# Altium schematic sheet SPI LVDS Master.SchDoc("SPI LVDS Master")
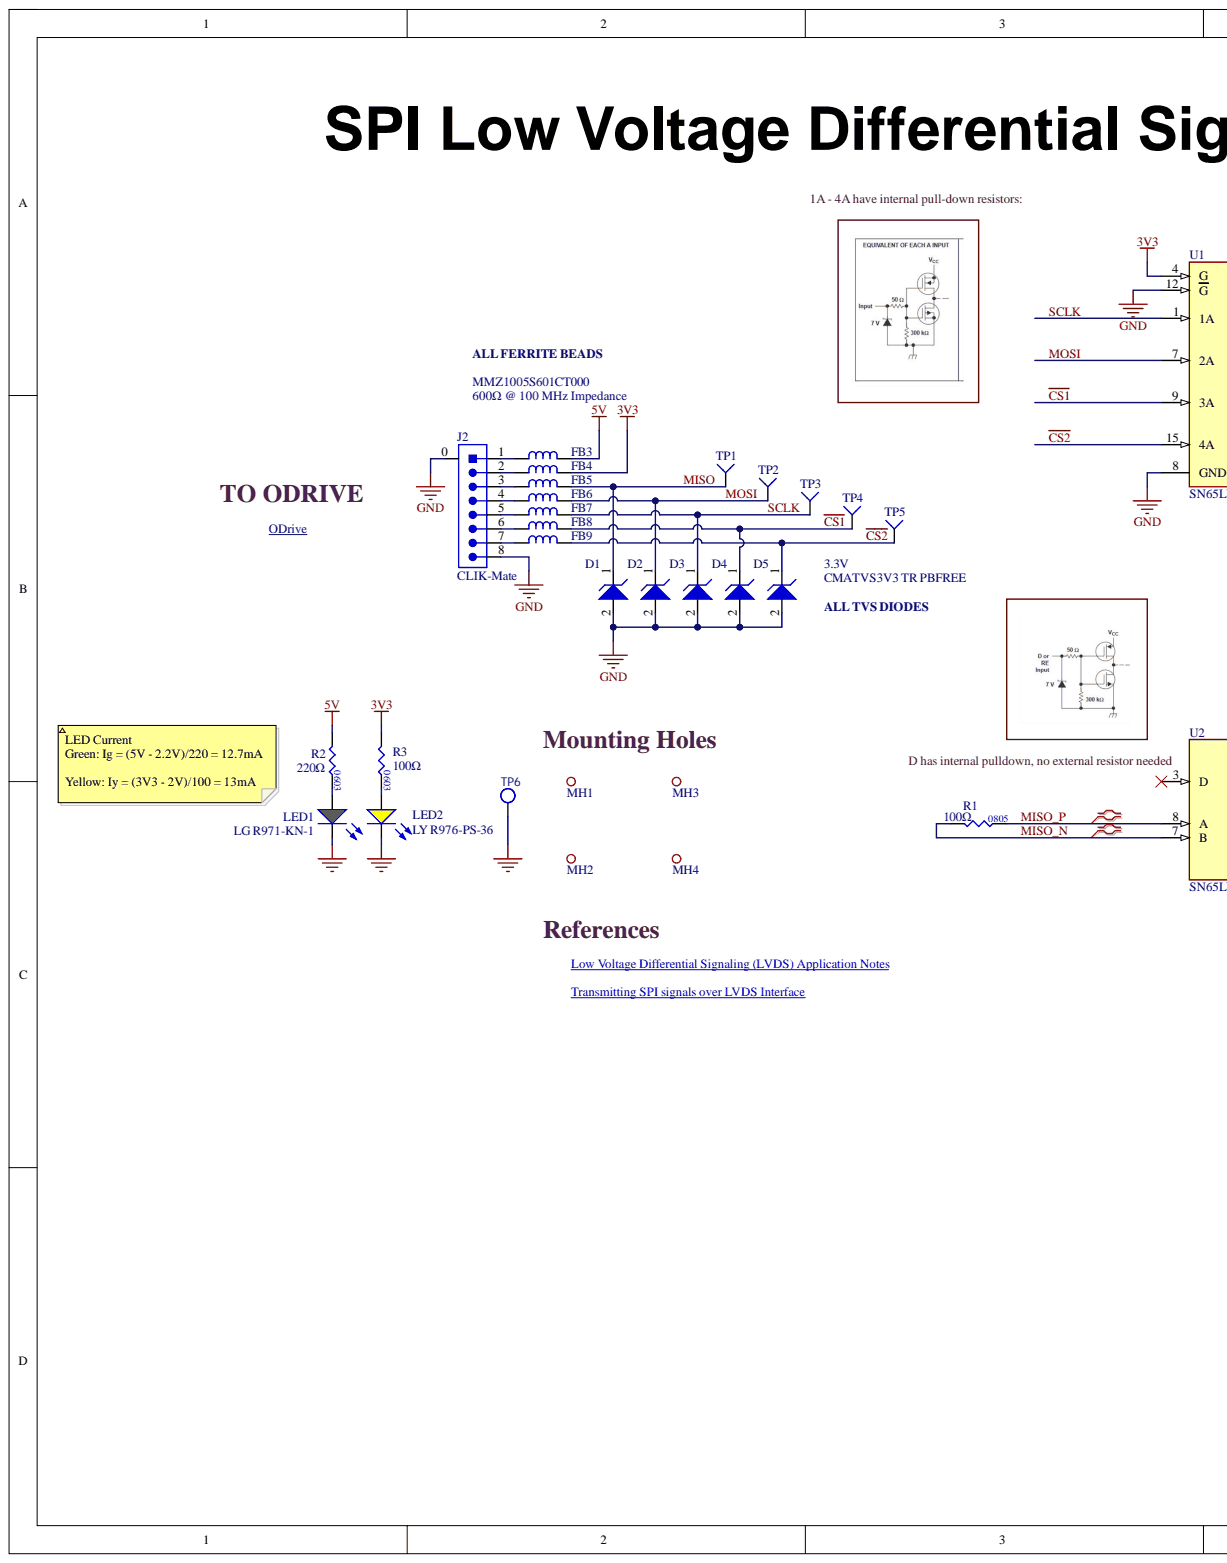
[diagram p0: SPI LVDS Master.SchDoc, left]
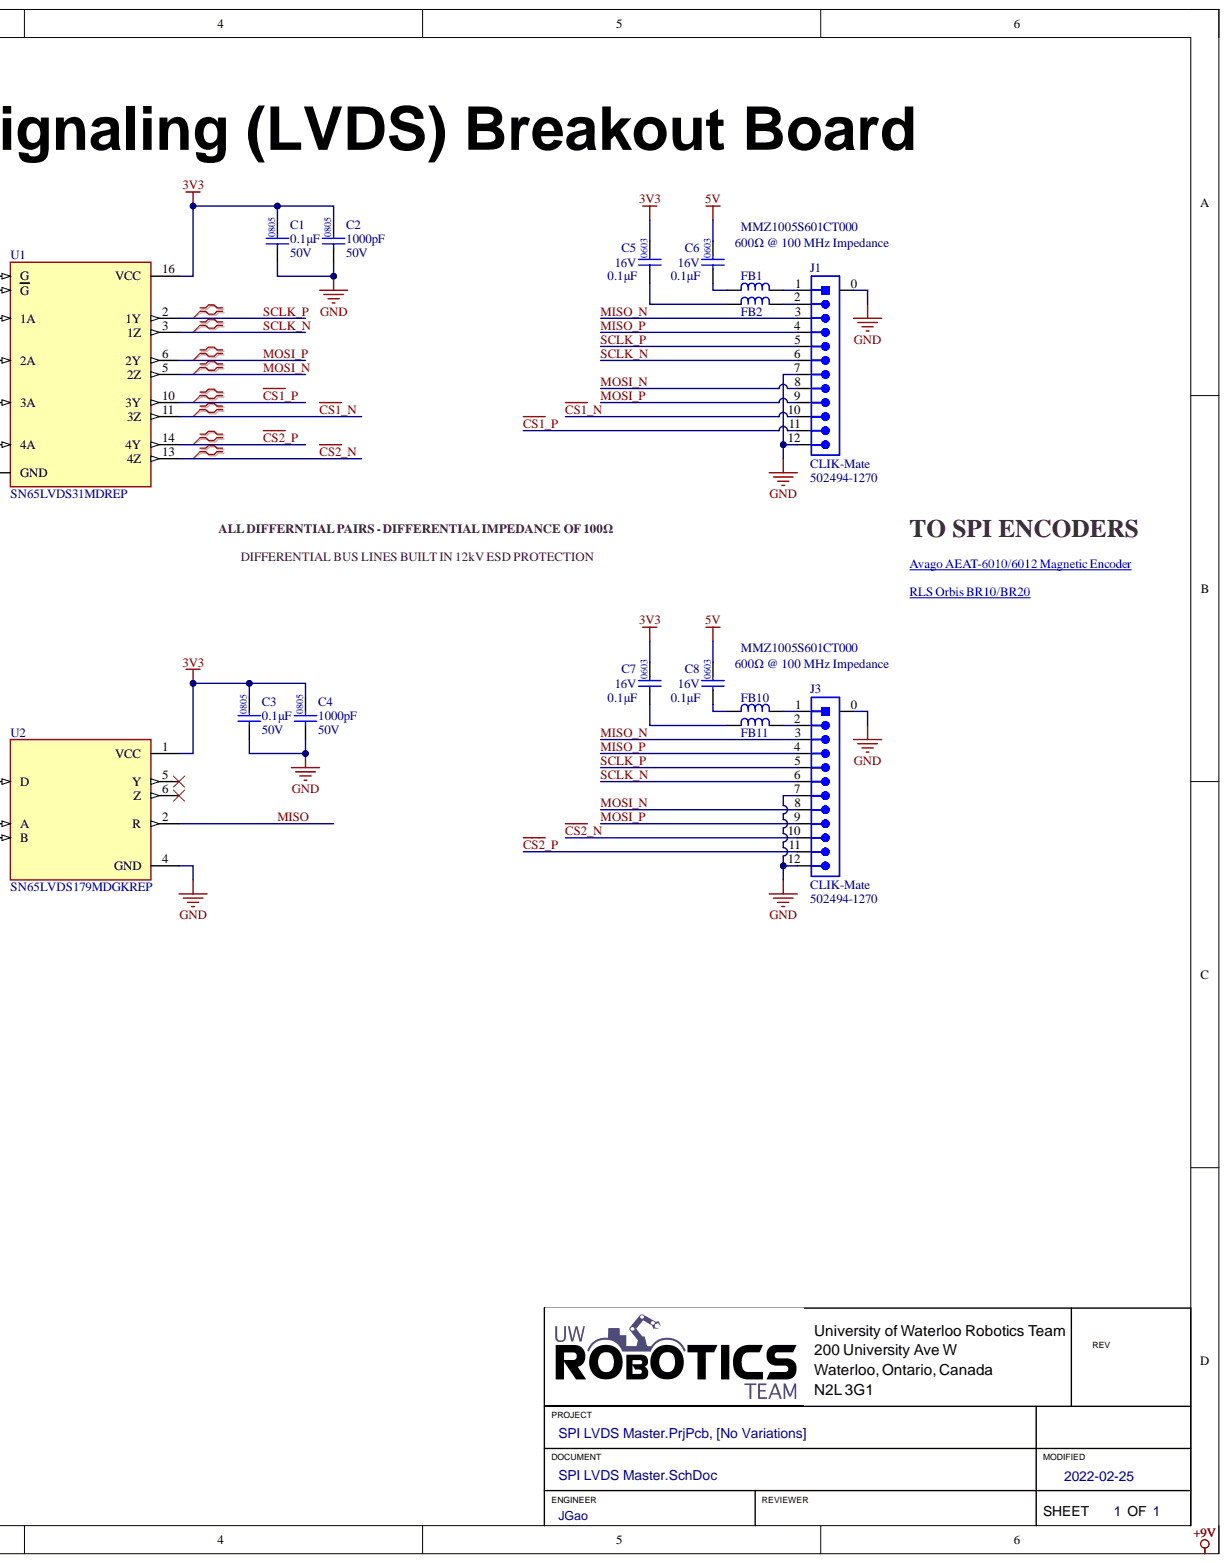
[diagram p1: SPI LVDS Master.SchDoc, right]

## Parts
C1  value 0.1μF  Package/Footprint: 0805  Voltage Rating: 50V  [CAP2012]  (hidden comment: CAP_0.1uF_50V_0805)
C2  value 1000pF  Package/Footprint: 0805  Voltage Rating: 50V  [CAP2012]  (hidden comment: CAP_1000pF_50V_0805)
C3  value 0.1μF  Package/Footprint: 0805  Voltage Rating: 50V  [CAP2012]  (hidden comment: CAP_0.1uF_50V_0805)
C4  value 1000pF  Package/Footprint: 0805  Voltage Rating: 50V  [CAP2012]  (hidden comment: CAP_1000pF_50V_0805)
C5  value 0.1μF  Package: 0603  Voltage Rating: 16V  [CAP0603]  (hidden comment: CAP_0.1uF_16V_0603)
C6  value 0.1μF  Package: 0603  Voltage Rating: 16V  [CAP0603]  (hidden comment: CAP_0.1uF_16V_0603)
C7  value 0.1μF  Package: 0603  Voltage Rating: 16V  [CAP0603]  (hidden comment: CAP_0.1uF_16V_0603)
C8  value 0.1μF  Package: 0603  Voltage Rating: 16V  [CAP0603]  (hidden comment: CAP_0.1uF_16V_0603)
D1  TVS_DIODE_UNIDIR_3.3V_7A  [SOD-923]  (value not drawn)
D2  TVS_DIODE_UNIDIR_3.3V_7A  [SOD-923]  (value not drawn)
D3  TVS_DIODE_UNIDIR_3.3V_7A  [SOD-923]  (value not drawn)
D4  TVS_DIODE_UNIDIR_3.3V_7A  [SOD-923]  (value not drawn)
D5  Voltage - Reverse Standoff (Typ): 3.3V  [SOD-923]  (hidden comment: TVS_DIODE_UNIDIR_3.3V_7A)
FB1  FERRITE_BEAD_600OHM_0402  [RES0402]  (value not drawn)
FB2  FERRITE_BEAD_600OHM_0402  [RES0402]  (value not drawn)
FB3  FERRITE_BEAD_600OHM_0402  [RES0402]  (value not drawn)
FB4  FERRITE_BEAD_600OHM_0402  [RES0402]  (value not drawn)
FB5  FERRITE_BEAD_600OHM_0402  [RES0402]  (value not drawn)
FB6  FERRITE_BEAD_600OHM_0402  [RES0402]  (value not drawn)
FB7  FERRITE_BEAD_600OHM_0402  [RES0402]  (value not drawn)
FB8  FERRITE_BEAD_600OHM_0402  [RES0402]  (value not drawn)
FB9  FERRITE_BEAD_600OHM_0402  [RES0402]  (value not drawn)
FB10  FERRITE_BEAD_600OHM_0402  [RES0402]  (value not drawn)
FB11  FERRITE_BEAD_600OHM_0402  [RES0402]  (value not drawn)
J1  Family: CLIK-Mate  Manufacturer Part Number: 502494-1270  [MOLEX-5024941270]  (hidden comment: CON_12M_SM_RA_2mm)
J2  Family: CLIK-Mate  [MOLEX-5025850870]  (hidden comment: CON_8M_SM_RA)
J3  Family: CLIK-Mate  Manufacturer Part Number: 502494-1270  [MOLEX-5024941270]  (hidden comment: CON_12M_SM_RA_2mm)
LED1  LED_GREEN  [LED_0805_GREEN]  (value not drawn)
LED2  Manufacturer Part Number 1: LY R976-PS-36  [LED_0805_YELLOW]  (hidden comment: LED_YELLOW)
MH1  MOUNTING_HOLE_M3  [MOUNTING_HOLE_3mm]  (value not drawn)  (no BOM)
MH2  MOUNTING_HOLE_M3  [MOUNTING_HOLE_3mm]  (value not drawn)  (no BOM)
MH3  MOUNTING_HOLE_M3  [MOUNTING_HOLE_3mm]  (value not drawn)  (no BOM)
MH4  MOUNTING_HOLE_M3  [MOUNTING_HOLE_3mm]  (value not drawn)  (no BOM)
R1  value 100Ω  Package/Footprint: 0805  [RES0805]  (hidden comment: RES_100Ω_0805_1%)
R2  value 220Ω  Package/Footprint: 0603  [RES0603]  (hidden comment: RES_220Ω_0603_5%)
R3  value 100Ω  [RES0603]  (hidden comment: RES_100Ω_0603_1%)
TP1  TESTPOINT_1MM_SQUARE  [TESTPOINT_SQUARE_1MM]  (value not drawn)  (no BOM)
TP2  TESTPOINT_1MM_SQUARE  [TESTPOINT_SQUARE_1MM]  (value not drawn)  (no BOM)
TP3  TESTPOINT_1MM_SQUARE  [TESTPOINT_SQUARE_1MM]  (value not drawn)  (no BOM)
TP4  TESTPOINT_1MM_SQUARE  [TESTPOINT_SQUARE_1MM]  (value not drawn)  (no BOM)
TP5  TESTPOINT_1MM_SQUARE  [TESTPOINT_SQUARE_1MM]  (value not drawn)  (no BOM)
TP6  TESTPOINT_LOOP  [TESTPOINT_LOOP]  (value not drawn)
U1  SN65LVDS31MDREP  [SOIC127P600X175-16N]
U2  SN65LVDS179MDGKREP  [SOP65P490X110-8N]

## Nets
3V3: C1.2 C2.2 C3.2 C4.2 C5.2 C7.2 FB4.2 R3.1 U1.4(G) U1.16(VCC) U2.1(VCC)
5V: C6.2 C8.2 FB3.2 R2.1
GND: C1.1 C2.1 C3.1 C4.1 D1.2 D2.2 D3.2 D4.2 D5.2 J1.0 J1.7 J1.12 J2.0 J2.8 J3.0 J3.7 J3.12 LED1.2 LED2.2 TP6.1 U1.8(GND) U1.12(G) U2.4(GND)
~{CS1}: D4.1 FB8.2 TP4.1 U1.9(3A)
~{CS1}_N: J1.10 U1.11(3Z)
~{CS1}_P: J1.11 U1.10(3Y)
~{CS2}: D5.1 FB9.2 TP5.1 U1.15(4A)
~{CS2}_N: J3.10 U1.13(4Z)
~{CS2}_P: J3.11 U1.14(4Y)
MISO: D1.1 FB5.2 TP1.1 U2.2(R)
MISO_N: J1.3 J3.3 R1.1 U2.7(B)
MISO_P: J1.4 J3.4 R1.2 U2.8(A)
MOSI: D2.1 FB6.2 TP2.1 U1.7(2A)
MOSI_N: J1.8 J3.8 U1.5(2Z)
MOSI_P: J1.9 J3.9 U1.6(2Y)
SCLK: D3.1 FB7.2 TP3.1 U1.1(1A)
SCLK_N: J1.6 J3.6 U1.3(1Z)
SCLK_P: J1.5 J3.5 U1.2(1Y)
NetC5_1: C5.1 FB2.2
NetC6_1: C6.1 FB1.2
NetC7_1: C7.1 FB11.2
NetC8_1: C8.1 FB10.2
NetFB1_1: FB1.1 J1.1
NetFB2_1: FB2.1 J1.2
NetFB3_1: FB3.1 J2.1
NetFB4_1: FB4.1 J2.2
NetFB5_1: FB5.1 J2.3
NetFB6_1: FB6.1 J2.4
NetFB7_1: FB7.1 J2.5
NetFB8_1: FB8.1 J2.6
NetFB9_1: FB9.1 J2.7
NetFB10_1: FB10.1 J3.1
NetFB11_1: FB11.1 J3.2
NetLED1_1: LED1.1 R2.2
NetLED2_1: LED2.1 R3.2
+9V:

Not connected: U2 3(D) 5(Y) 6(Z)

## Library data of the parts
C1 C3 = CAP_0.1uF_50V_0805: CAP CER 0.1UF 50V X7R 0805; Manufacturer 1: Murata Electronics; Manufacturer Part Number 1: GCM21BR71H104KA37K; Component Kind: Standard; Dielectric: X7R; LCSC Part #: C49678; Mounting Type: SMD; Supplier 1: Digi-Key; Supplier Part Number 1: 490-8049-1-ND; Library Name: UWRT_Schematic_Library_2020.SchLib
C2 C4 = CAP_1000pF_50V_0805: CAP CER 1000pF 50V X7R 0805; Manufacturer 1: Samsung; Manufacturer Part Number 1: CL21B102KBANNNC; Component Kind: Standard; Dielectric: X7R; Mounting Type: SMD; Supplier 1: Digi-Key; Supplier Part Number 1: 1276-1020-1-ND; Library Name: UWRT_Schematic_Library_2020.SchLib
C5-C8 = CAP_0.1uF_16V_0603: CAP CER 0.1UF 16V X7R 0603; Manufacturer 1: Yageo; Manufacturer Part Number 1: CC0603KRX7R7BB104; Component Kind: Standard; Dielectric: X7R; Supplier 1: Digi-Key; Supplier Part Number 1: 311-1088-1-ND; Library Name: UWRT_Schematic_Library_2020.SchLib
D1-D4 = TVS_DIODE_UNIDIR_3.3V_7A: TVS DIODE 3.3VWM 11VC SOD923; Manufacturer 1: Central Semiconductor Corp; Manufacturer Part Number 1: CMATVS3V3 TR PBFREE; Voltage - Breakdown (Min): 5V; Voltage - Clamping (Max) @ Ipp: 11V; Voltage - Reverse Standoff (Typ): 3.3V; Component Kind: Standard; Mounting Type: SMD; Package/Footprint: SOD-923; Supplier 1: Digi-Key; Supplier Part Number 1: 1514-CMATVS3V3TRPBFREECT-ND; Library Name: UWRT_Schematic_Library_2020.SchLib
D5 = TVS_DIODE_UNIDIR_3.3V_7A: TVS DIODE 3.3VWM 11VC SOD923; Manufacturer 1: Central Semiconductor Corp; Manufacturer Part Number 1: CMATVS3V3 TR PBFREE; Voltage - Breakdown (Min): 5V; Voltage - Clamping (Max) @ Ipp: 11V; Component Kind: Standard; Mounting Type: SMD; Package/Footprint: SOD-923; Supplier 1: Digi-Key; Supplier Part Number 1: 1514-CMATVS3V3TRPBFREECT-ND; Library Name: UWRT_Schematic_Library_2020.SchLib
FB1-FB11 = FERRITE_BEAD_600OHM_0402: FIXED IND 1UH 3.8A 33 MOHM SMD; Manufacturer 1: TDK Corporation; Manufacturer Part Number 1: MMZ1005S601CT000; Current Rating (Max): 300mA; DC Resistance (DCR) (Max): 520mΩ; Impedance: 600Ω; Impedance @ Frequency: 600Ω @ 100 MHz; Component Kind: Standard; Mounting Type: SMD; Package: 0402; Package/Footprint: SMD; Supplier 1: Digi-Key; Supplier Part Number 1: 445-2162-1-ND; Library Name: UWRT_Schematic_Library_2020.SchLib
J1 J3 = CON_12M_SM_RA_2mm: 2.00mm Pitch CLIK-Mate Wire-to-Board PCB Receptacle, Single Row, Right-Angle, 12 Circuits; Manufacturer: Molex; Contact Resistance: 20mR; Current Rating: 3A; Glow Wire Compliant: Non-compliant; Insulation Resistance: 1GR; Mating Cycles: 30; Max Voltage Rating (AC): 250V; Number of Circuits: 12; Pitch: 2mm; Category: Headers and Wire Housings; Color: Natural,White; Component Kind: Standard; Connector Type: Receptacle; Contact Gender: Female; Contact Material: Copper Alloy; Contact Plating: Tin; Contact Type: Center Strip Contact; Depth: 6.85mm; Fastening Type: Latch; Flammability Rating: UL94 V-0; Gender: Receptacle; Housing Color: Natural; Housing Material: PBT; Lead Pitch: 2mm; Length: 28mm; Material: Polybutylene; Max Operating Temperature: 85°C; Max Voltage Rating (DC): 250V; Min Operating Temperature: -25°C; Mount: Surface Mount; Number of Contacts: 12; Number of Positions: 12; Number of Rows: 1; Orientation: Right Angle; Package Quantity: 950; Packaging: Tape and Reel; Pricing 1: 950=0.62780, 1900=0.61060, 3800=0.56580, 5700=0.53600, 7600=0.52000 (USD); Radiation Hardening: No; REACH SVHC: Unknown; RoHS: Yes; Series: 502494; Stock 1: 1900; Supplier 1: Mouser; Supplier Part Number 1: 502494-1270; Termination: Solder; Voltage Rating (AC): 250V; Library Name: UWRT_Schematic_Library_2020.SchLib
J2 = CON_8M_SM_RA: CONN HEADER SMD RIGHT ANGLE 1.5 MM PITCH; Current Rating: 2A; Pitch: 1.5mm; Voltage Rating: 100V; Component Kind: Standard; Mounting Type: SMD; Orientation: Right Angle; Package/Footprint: SMD; Supplier 1: Digi-Key; Supplier Part Number 1: WM14471CT-ND; Library Name: UWRT_Schematic_Library_2020.SchLib
LED1 = LED_GREEN: LED GREEN DIFFUSED 0805 SMD; Manufacturer 1: OSRAM; Manufacturer Part Number 1: LG R971-KN-1; Forward Voltage Drop: 2.2V; Package/Footprint: 0805; Component Kind: Standard; Mounting Type: SMD; Supplier 1: Digi-Key; Supplier Part Number 1: 475-1410-1-ND; Library Name: UWRT_Schematic_Library_2020.SchLib
LED2 = LED_YELLOW: LED YELLOW DIFFUSED 0805 SMD; Manufacturer 1: OSRAM; Forward Voltage Drop: 2.2V; Component Kind: Standard; Package: 0805 (2012 Metric); Supplier 1: Digi-Key; Supplier Part Number 1: 475-2560-1-ND; Library Name: UWRT_Schematic_Library_2020.SchLib
R1 = RES_100Ω_0805_1%: RES 100 OHM 1% 1/8W 0805; Manufacturer 1: Vishay Dale; Manufacturer Part Number 1: CRCW0805100RFKEA; Component Kind: Standard; LCSC Part #: C1790; Mounting Type: SMD; Supplier 1: Digi-Key; Supplier Part Number 1: 541-100CCT-ND; Library Name: UWRT_Schematic_Library_2020.SchLib
R2 = RES_220Ω_0603_5%: RES 220 OHM 5% 1/10W 0603; Manufacturer 1: Yageo; Manufacturer Part Number 1: AC0603JR-07220RL; Component Kind: Standard; Supplier 1: Digi-Key; Supplier Part Number 1: YAG3652CT-ND; Library Name: UWRT_Schematic_Library_2020.SchLib
R3 = RES_100Ω_0603_1%: RES 100 OHM 1% 1/10W 0603; Manufacturer 1: Yageo; Manufacturer Part Number 1: RC0603FR-07100RL; Component Kind: Standard; Supplier 1: Digi-Key; Supplier Part Number 1: 311-100HRCT-ND; Library Name: UWRT_Schematic_Library_2020.SchLib
TP1-TP5 = TESTPOINT_1MM_SQUARE: TESTPOINT 1MM SQUARE; Library Name: UWRT_Schematic_Library_2020.SchLib
TP6 = TESTPOINT_LOOP: TESTPOINT THRUHOLE; Manufacturer 1: Keystone Electronics; Manufacturer Part Number 1: 5002; Package/Footprint: TH; Component Kind: Standard; Mounting Type: TH; Supplier 1: Digi-Key; Supplier Part Number 1: 36-5002-ND; Library Name: UWRT_Schematic_Library_2020.SchLib
U1 = SN65LVDS31MDREP: IC DRIVER 4/0 16SOIC; Availability: Unavailable; Component Kind: Standard; MP: SN65LVDS31MDREP; Package: None; Price: None; Supplier 1: Digi-Key; Supplier Part Number 1: 296-36715-1-ND; Library Name: UWRT_Schematic_Library_2020.SchLib
U2 = SN65LVDS179MDGKREP: Enhanced Product High-Speed Differential Line Drivers And Receivers 8-VSSOP -55 to 125; MF: Texas Instruments; Component Kind: Standard; Digi-Key_Part_Number: 296-22243-1-ND; MP: SN65LVDS179MDGKREP; Package: VSSOP-8 Texas Instruments; Purchase-URL: https://pricing.snapeda.com/search/part/SN65LVDS179MDGKREP/?ref=eda; SnapEDA_Link: https://www.snapeda.com/parts/SN65LVDS179MDGKREP/Texas%20Instruments/view-part/383554/?ref=snap; Supplier 1: Digi-Key; Supplier Part Number 1: 296-22243-1-ND; Library Name: UWRT_Schematic_Library_2020.SchLib
MH1-MH4 = MOUNTING_HOLE_M3: Description: MOUNTING_HOLE_M3; Library Name: UWRT_Schematic_Library_2020.SchLib
